# Altium schematic sheet Random.SchDoc
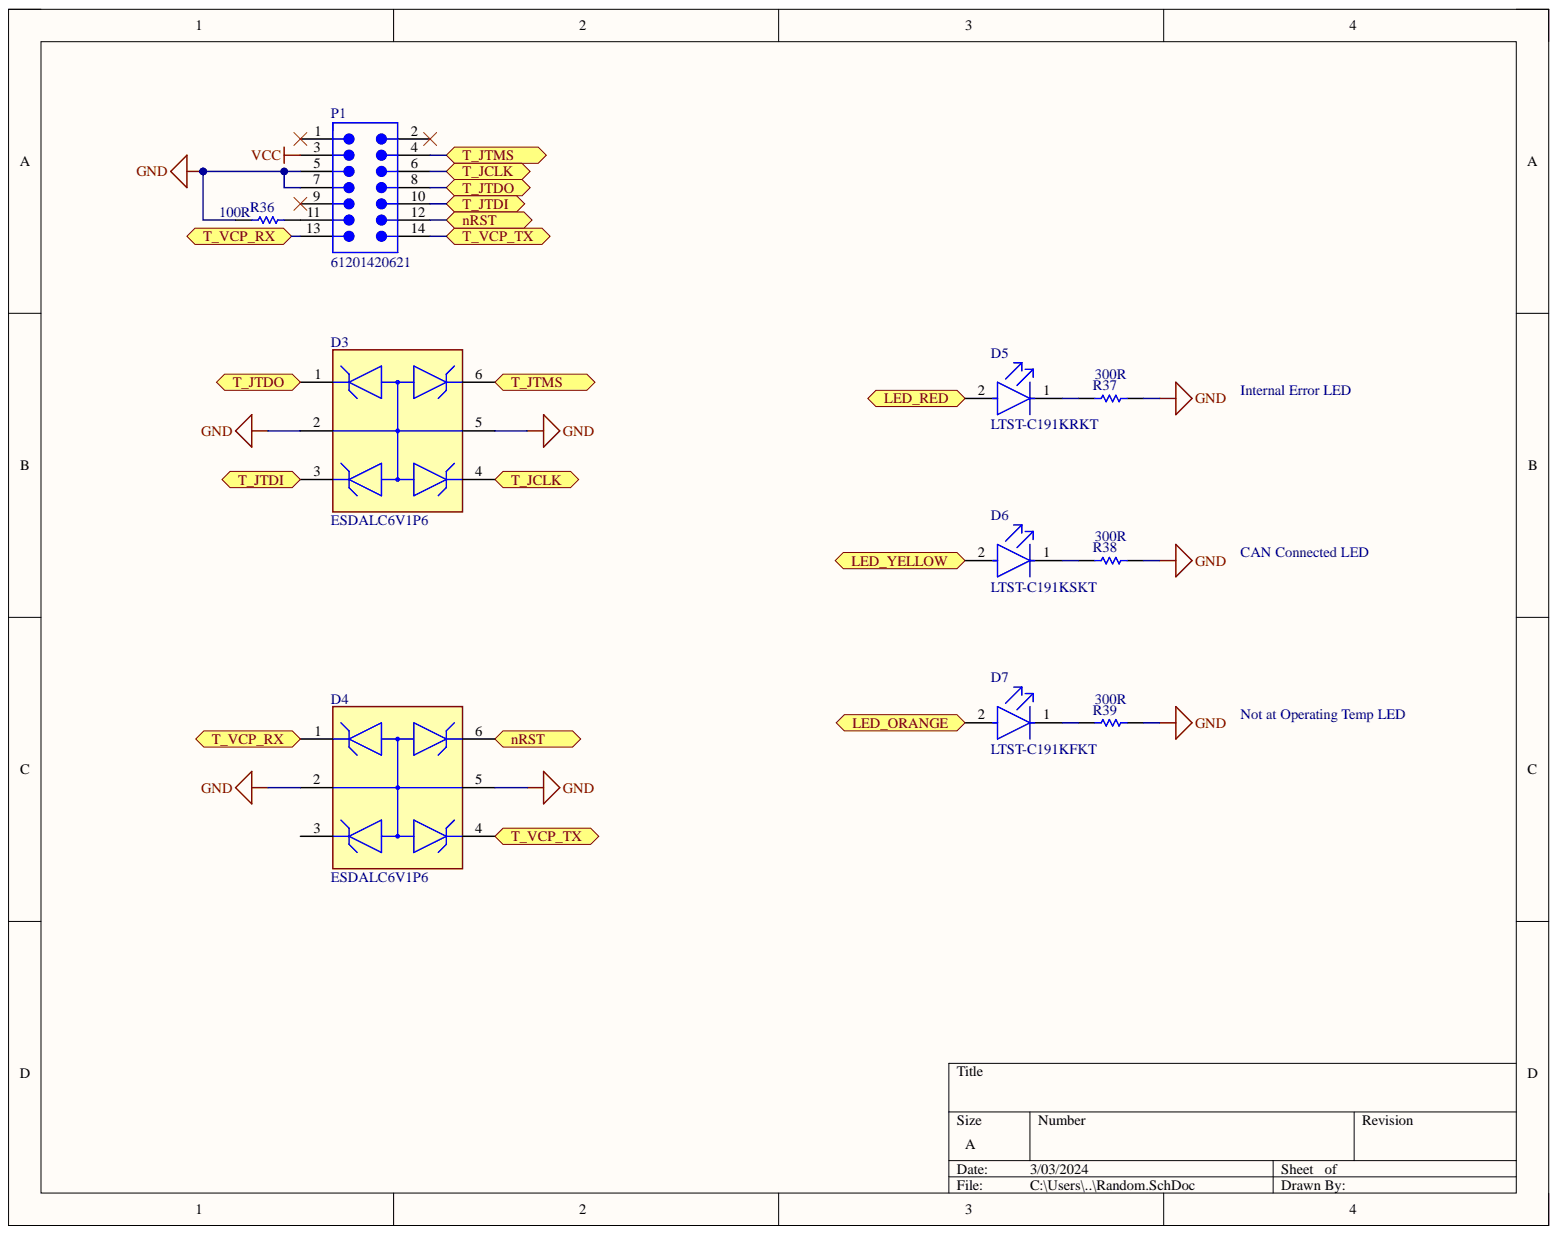
[diagram p0: Random.SchDoc]

## Parts
D3  ESDALC6V1P6  [FP-SOT-666IP-MFG]
D4  ESDALC6V1P6  [FP-SOT-666IP-MFG]
D5  LTST-C191KRKT  [FP-LTST-C191KSKT-MFG]
D6  LTST-C191KSKT  [FP-LTST-C191KSKT-MFG]
D7  LTST-C191KFKT  [FP-LTST-C191KSKT-MFG]
P1  61201420621  [61201420621]
R36  100R  [RESC1608X55X30LL20T20]
R37  300R  [RESC1608X55X30LL20T20]
R38  300R  [RESC1608X55X30LL20T20]
R39  300R  [RESC1608X55X30LL20T20]

## Ports
LED_ORANGE, LED_RED, LED_YELLOW, nRST, T_JCLK, T_JTDI, T_JTDO, T_JTMS, T_VCP_RX, T_VCP_TX

## Nets
GND: D3.2 D3.5 D4.2 D4.5 P1.5 P1.7 R36.2 R37.2 R38.2 R39.2
VCC: P1.3
LED_ORANGE: D7.2 ; port LED_ORANGE
LED_RED: D5.2 ; port LED_RED
LED_YELLOW: D6.2 ; port LED_YELLOW
nRST: D4.6 P1.12 ; port nRST
T_JCLK: D3.4 P1.6 ; port T_JCLK
T_JTDI: D3.3 P1.10 ; port T_JTDI
T_JTDO: D3.1 P1.8 ; port T_JTDO
T_JTMS: D3.6 P1.4 ; port T_JTMS
T_VCP_RX: D4.1 P1.13 ; port T_VCP_RX
T_VCP_TX: D4.4 P1.14 ; port T_VCP_TX
NetD5_1: D5.1 R37.1
NetD6_1: D6.1 R38.1
NetD7_1: D7.1 R39.1
NetP1_11: P1.11 R36.1

Not connected: D4 3; P1 1 2 9

## Library data of the parts
D3 D4 = CMP-12225-000016-1: TVS DIODE 3V SOT666IP; Manufacturer: STMicroelectronics; Breakdown Voltage: 6.1V; Capacitance: 7.5pF; Direction: Unidirectional; Max Junction Temp: +150°C; Number Of Channels: 4; Peak Pulse Power: 30W; Value: 7.5pF; Automotive: No; Category: Diode; Component Kind: Standard; ComponentLink2Description: Datasheet; ComponentLink2URL: https://www.st.com/resource/en/datasheet/esdalc6v1px.pdf; Device Class L1: Circuit Protection; Device Class L2: TVS Diodes; Device Class L3: unset; Digikey Description: TVS DIODE 3V SOT666IP; Height: 0.62mm; Lead Free: Yes; Library Name: Altium Content Vault; Manufacturer Part Number: ESDALC6V1P6; Mouser Description: ESDALC Series 4 Channel 6.1V Uni-Directional Transil? ESD Protection - SOT-666IP; Octopart: https://octopart.com/esdalc6v1p6-stmicroelectronics-347727; Package: SOT-666IP; Reverse Standoff Voltage: 3V; RoHS: TRUE; Temperature: -40°C to +150°C; Temperature Range High: +150°C; Temperature Range Low: -40°C
D5-D7 = CMP-22017-000025-1: LED YELLOW CLEAR SMD; Dominant Wavelength: 597nm; Forward Current: 30mA; Forward Voltage: 2.4V; Lens Color: Colorless; Lens Style: Diffused; Luminous Intensity: 180mcd; Number of Elements: 1; Power Dissipation: 75mW; Reverse Voltage: 5V; Test Current: 20mA; Viewing Angle: 130°; Wavelength: 588nm; Case Code (Imperial): 0603; Case Code (Metric): 1608; Case/Package: 0603; Color: Yellow; Component Kind: Standard; Depth: 0.8mm; Height: 0.55mm; Illumination Color: Yellow; Lead Free: Lead Free; Length: 1.6mm; Lens Transparency: Clear; Library Name: Altium Content Vault; Max Operating Temperature: 85°C; Min Operating Temperature: -55°C; Mount: Surface Mount; Number of LEDs: 1; Number of Pins: 2; Package Quantity: 1; Packaging: Tape and Reel; Power Rating: 75mW; Radiation Hardening: No; Width: 0.8mm
P1 = CMP-1502-00053-2: NexarPartId: 24514104; Connector Type: Male Pin; Contact Resistance: 20mΩ; Gender: Male; Number of Contacts: 14; Operating Supply Voltage: 250V; Pitch: 2.54mm; Color: Black; Component Kind: Standard; Contact Material: Copper; Contact Mating Length: 5.08mm; Contact Plating: Gold; Lead Pitch: 2.54mm; Library Name: Altium Content Vault; Mount: Surface Mount; Number of Pins: 14; Number of Positions: 14; Number of Rows: 2; Orientation: Vertical; REACH SVHC: Unknown; RoHS: Compliant; Row Spacing: 2.54mm; Termination: Solder
R36-R39 = CMP-2000-00025-1: Manufacturer: Panasonic; Part Number: ERA3AEB301V; Component Kind: Standard; Library Name: Altium Content Vault
